# Altium schematic sheet 2008_SablierElectronique_MosfetConnections.SchDoc
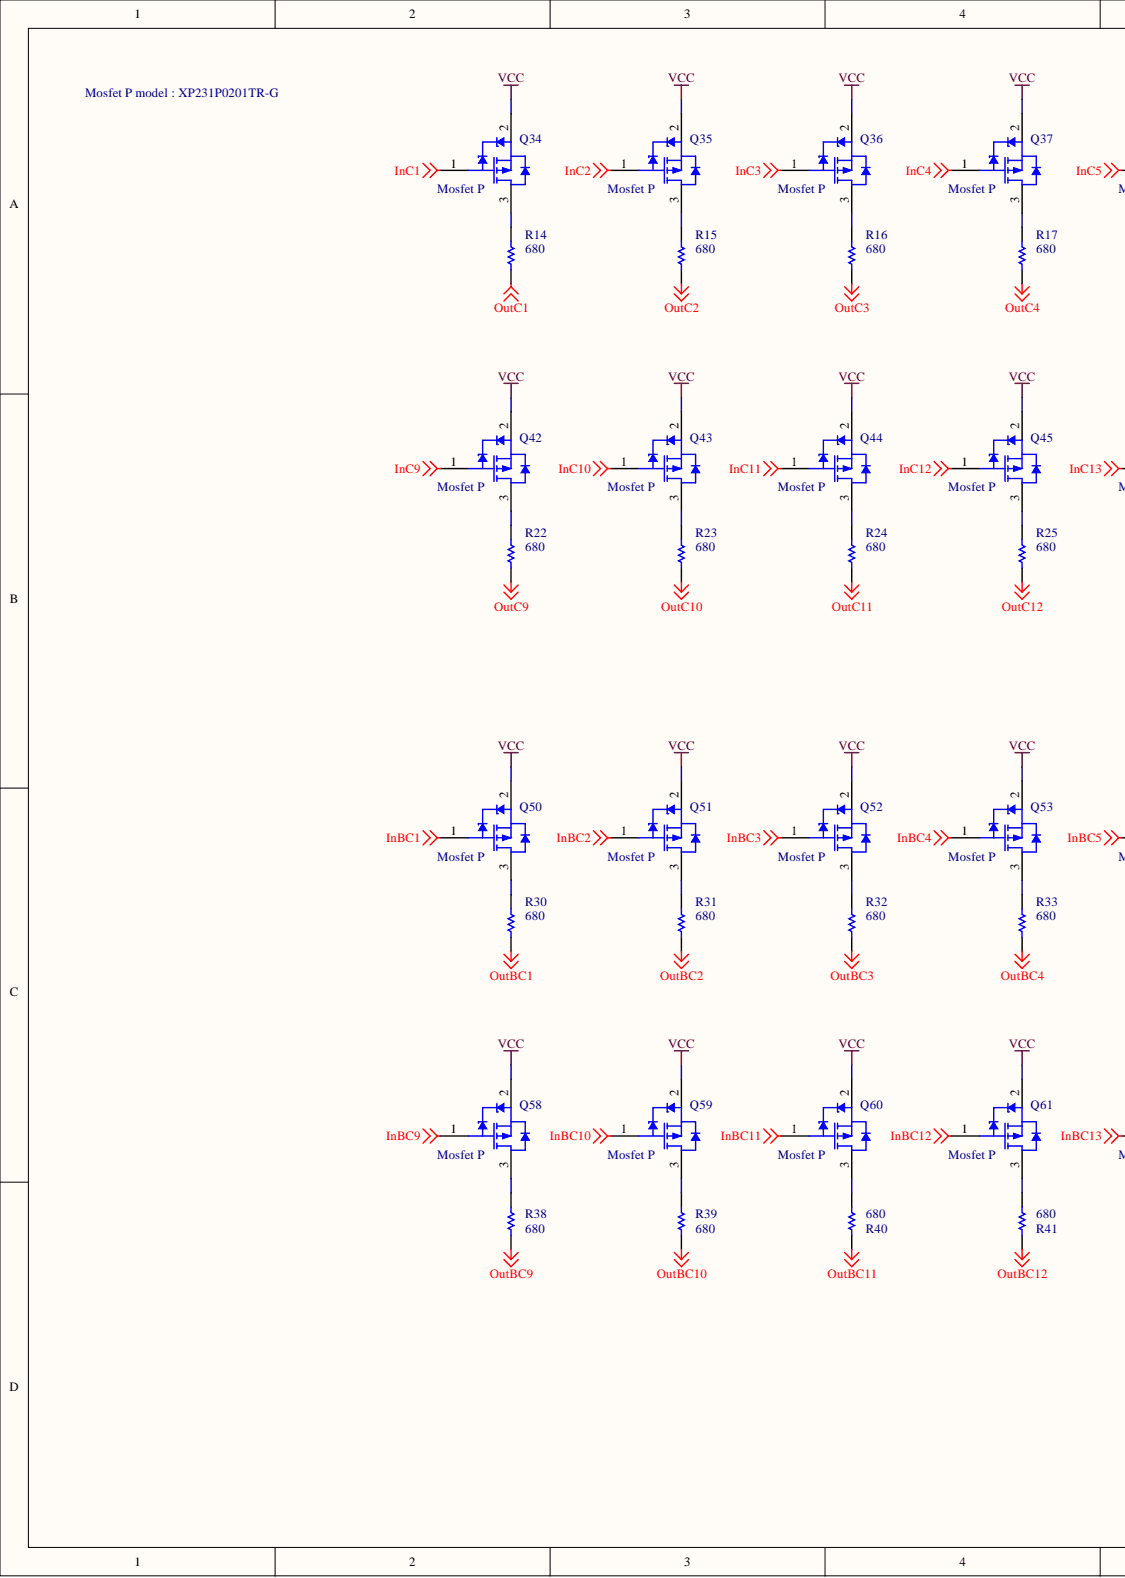
[diagram p0: 2008_SablierElectronique_MosfetConnections.SchDoc, left]
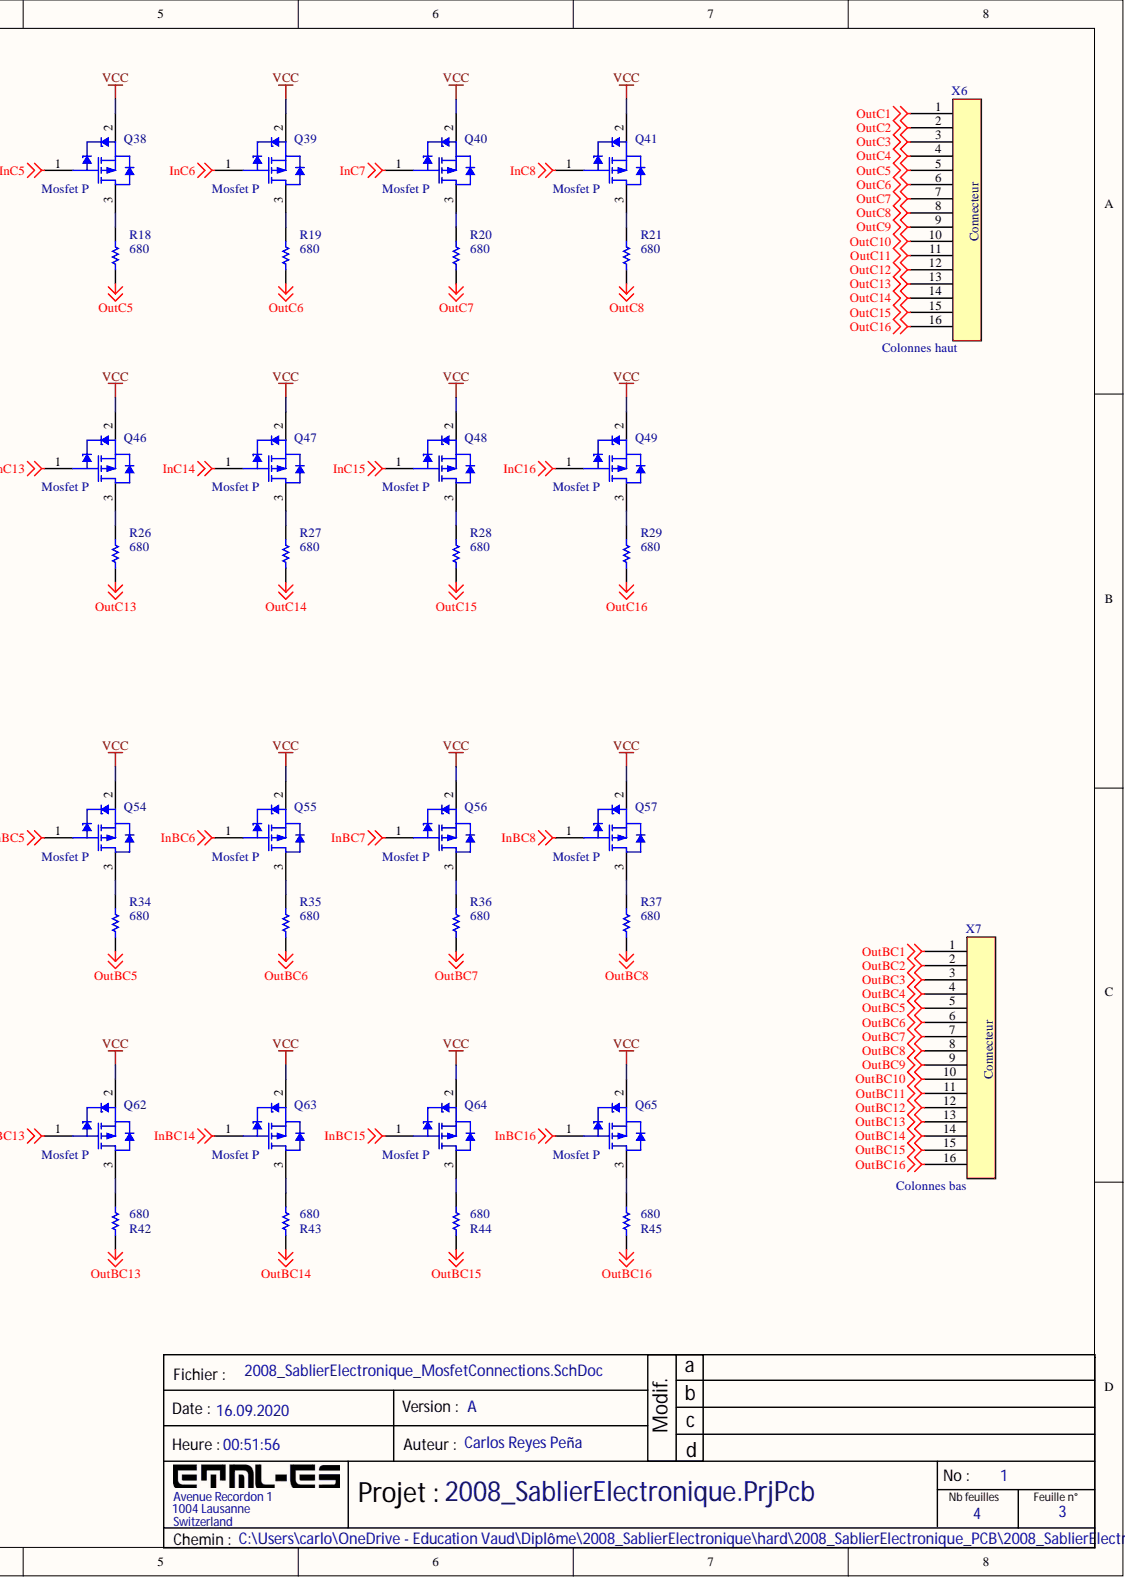
[diagram p1: 2008_SablierElectronique_MosfetConnections.SchDoc, right]

## Parts
Q34  Mosfet P  [FAIR-SOT23-3_V]
Q35  Mosfet P  [FAIR-SOT23-3_V]
Q36  Mosfet P  [FAIR-SOT23-3_V]
Q37  Mosfet P  [FAIR-SOT23-3_V]
Q38  Mosfet P  [FAIR-SOT23-3_V]
Q39  Mosfet P  [FAIR-SOT23-3_V]
Q40  Mosfet P  [FAIR-SOT23-3_V]
Q41  Mosfet P  [FAIR-SOT23-3_V]
Q42  Mosfet P  [FAIR-SOT23-3_V]
Q43  Mosfet P  [FAIR-SOT23-3_V]
Q44  Mosfet P  [FAIR-SOT23-3_V]
Q45  Mosfet P  [FAIR-SOT23-3_V]
Q46  Mosfet P  [FAIR-SOT23-3_V]
Q47  Mosfet P  [FAIR-SOT23-3_V]
Q48  Mosfet P  [FAIR-SOT23-3_V]
Q49  Mosfet P  [FAIR-SOT23-3_V]
Q50  Mosfet P  [FAIR-SOT23-3_V]
Q51  Mosfet P  [FAIR-SOT23-3_V]
Q52  Mosfet P  [FAIR-SOT23-3_V]
Q53  Mosfet P  [FAIR-SOT23-3_V]
Q54  Mosfet P  [FAIR-SOT23-3_V]
Q55  Mosfet P  [FAIR-SOT23-3_V]
Q56  Mosfet P  [FAIR-SOT23-3_V]
Q57  Mosfet P  [FAIR-SOT23-3_V]
Q58  Mosfet P  [FAIR-SOT23-3_V]
Q59  Mosfet P  [FAIR-SOT23-3_V]
Q60  Mosfet P  [FAIR-SOT23-3_V]
Q61  Mosfet P  [FAIR-SOT23-3_V]
Q62  Mosfet P  [FAIR-SOT23-3_V]
Q63  Mosfet P  [FAIR-SOT23-3_V]
Q64  Mosfet P  [FAIR-SOT23-3_V]
Q65  Mosfet P  [FAIR-SOT23-3_V]
R14  680  [RESC0805(2012)_L]
R15  680  [RESC0805(2012)_L]
R16  680  [RESC0805(2012)_L]
R17  680  [RESC0805(2012)_L]
R18  680  [RESC0805(2012)_L]
R19  680  [RESC0805(2012)_L]
R20  680  [RESC0805(2012)_L]
R21  680  [RESC0805(2012)_L]
R22  680  [RESC0805(2012)_L]
R23  680  [RESC0805(2012)_L]
R24  680  [RESC0805(2012)_L]
R25  680  [RESC0805(2012)_L]
R26  680  [RESC0805(2012)_L]
R27  680  [RESC0805(2012)_L]
R28  680  [RESC0805(2012)_L]
R29  680  [RESC0805(2012)_L]
R30  680  [RESC0805(2012)_L]
R31  680  [RESC0805(2012)_L]
R32  680  [RESC0805(2012)_L]
R33  680  [RESC0805(2012)_L]
R34  680  [RESC0805(2012)_L]
R35  680  [RESC0805(2012)_L]
R36  680  [RESC0805(2012)_L]
R37  680  [RESC0805(2012)_L]
R38  680  [RESC0805(2012)_L]
R39  680  [RESC0805(2012)_L]
R40  680  [RESC0805(2012)_L]
R41  680  [RESC0805(2012)_L]
R42  680  [RESC0805(2012)_L]
R43  680  [RESC0805(2012)_L]
R44  680  [RESC0805(2012)_L]
R45  680  [RESC0805(2012)_L]
X6  Colonnes haut  [CON8x8(edited)]
X7  Colonnes bas  [CON8x8(edited)]

## Nets
InBC1: Q50.1
InBC2: Q51.1
InBC3: Q52.1
InBC4: Q53.1
InBC5: Q54.1
InBC6: Q55.1
InBC7: Q56.1
InBC8: Q57.1
InBC9: Q58.1
InBC10: Q59.1
InBC11: Q60.1
InBC12: Q61.1
InBC13: Q62.1
InBC14: Q63.1
InBC15: Q64.1
InBC16: Q65.1
InC1: Q34.1
InC2: Q35.1
InC3: Q36.1
InC4: Q37.1
InC5: Q38.1
InC6: Q39.1
InC7: Q40.1
InC8: Q41.1
InC9: Q42.1
InC10: Q43.1
InC11: Q44.1
InC12: Q45.1
InC13: Q46.1
InC14: Q47.1
InC15: Q48.1
InC16: Q49.1
OutBC1: R30.1 X7.1
OutBC2: R31.1 X7.2
OutBC3: R32.1 X7.3
OutBC4: R33.1 X7.4
OutBC5: R34.1 X7.5
OutBC6: R35.1 X7.6
OutBC7: R36.1 X7.7
OutBC8: R37.1 X7.8
OutBC9: R38.1 X7.9
OutBC10: R39.1 X7.10
OutBC11: R40.1 X7.11
OutBC12: R41.1 X7.12
OutBC13: R42.1 X7.13
OutBC14: R43.1 X7.14
OutBC15: R44.1 X7.15
OutBC16: R45.1 X7.16
OutC1: R14.1 X6.1
OutC2: R15.1 X6.2
OutC3: R16.1 X6.3
OutC4: R17.1 X6.4
OutC5: R18.1 X6.5
OutC6: R19.1 X6.6
OutC7: R20.1 X6.7
OutC8: R21.1 X6.8
OutC9: R22.1 X6.9
OutC10: R23.1 X6.10
OutC11: R24.1 X6.11
OutC12: R25.1 X6.12
OutC13: R26.1 X6.13
OutC14: R27.1 X6.14
OutC15: R28.1 X6.15
OutC16: R29.1 X6.16
VCC: Q34.2 Q35.2 Q36.2 Q37.2 Q38.2 Q39.2 Q40.2 Q41.2 Q42.2 Q43.2 Q44.2 Q45.2 Q46.2 Q47.2 Q48.2 Q49.2 Q50.2 Q51.2 Q52.2 Q53.2 Q54.2 Q55.2 Q56.2 Q57.2 Q58.2 Q59.2 Q60.2 Q61.2 Q62.2 Q63.2 Q64.2 Q65.2
NetQ34_3: Q34.3 R14.2
NetQ35_3: Q35.3 R15.2
NetQ36_3: Q36.3 R16.2
NetQ37_3: Q37.3 R17.2
NetQ38_3: Q38.3 R18.2
NetQ39_3: Q39.3 R19.2
NetQ40_3: Q40.3 R20.2
NetQ41_3: Q41.3 R21.2
NetQ42_3: Q42.3 R22.2
NetQ43_3: Q43.3 R23.2
NetQ44_3: Q44.3 R24.2
NetQ45_3: Q45.3 R25.2
NetQ46_3: Q46.3 R26.2
NetQ47_3: Q47.3 R27.2
NetQ48_3: Q48.3 R28.2
NetQ49_3: Q49.3 R29.2
NetQ50_3: Q50.3 R30.2
NetQ51_3: Q51.3 R31.2
NetQ52_3: Q52.3 R32.2
NetQ53_3: Q53.3 R33.2
NetQ54_3: Q54.3 R34.2
NetQ55_3: Q55.3 R35.2
NetQ56_3: Q56.3 R36.2
NetQ57_3: Q57.3 R37.2
NetQ58_3: Q58.3 R38.2
NetQ59_3: Q59.3 R39.2
NetQ60_3: Q60.3 R40.2
NetQ61_3: Q61.3 R41.2
NetQ62_3: Q62.3 R42.2
NetQ63_3: Q63.3 R43.2
NetQ64_3: Q64.3 R44.2
NetQ65_3: Q65.3 R45.2

## Library data of the parts
R14-R45 = RES: Component Kind: Standard; Library Name: 2008_SablierElectronique_SCHLIB.SchLib
X6 X7 = Mâle DIN 8 x 8: Component Kind: Standard; Library Name: 2008_SablierElectronique_SCHLIB.SchLib
Q34-Q65 = Mosfet P: Component Kind: Standard; Library Name: 2008_SablierElectronique_SCHLIB.SchLib
